# Altium schematic sheet PWR.SchDoc(PWR)
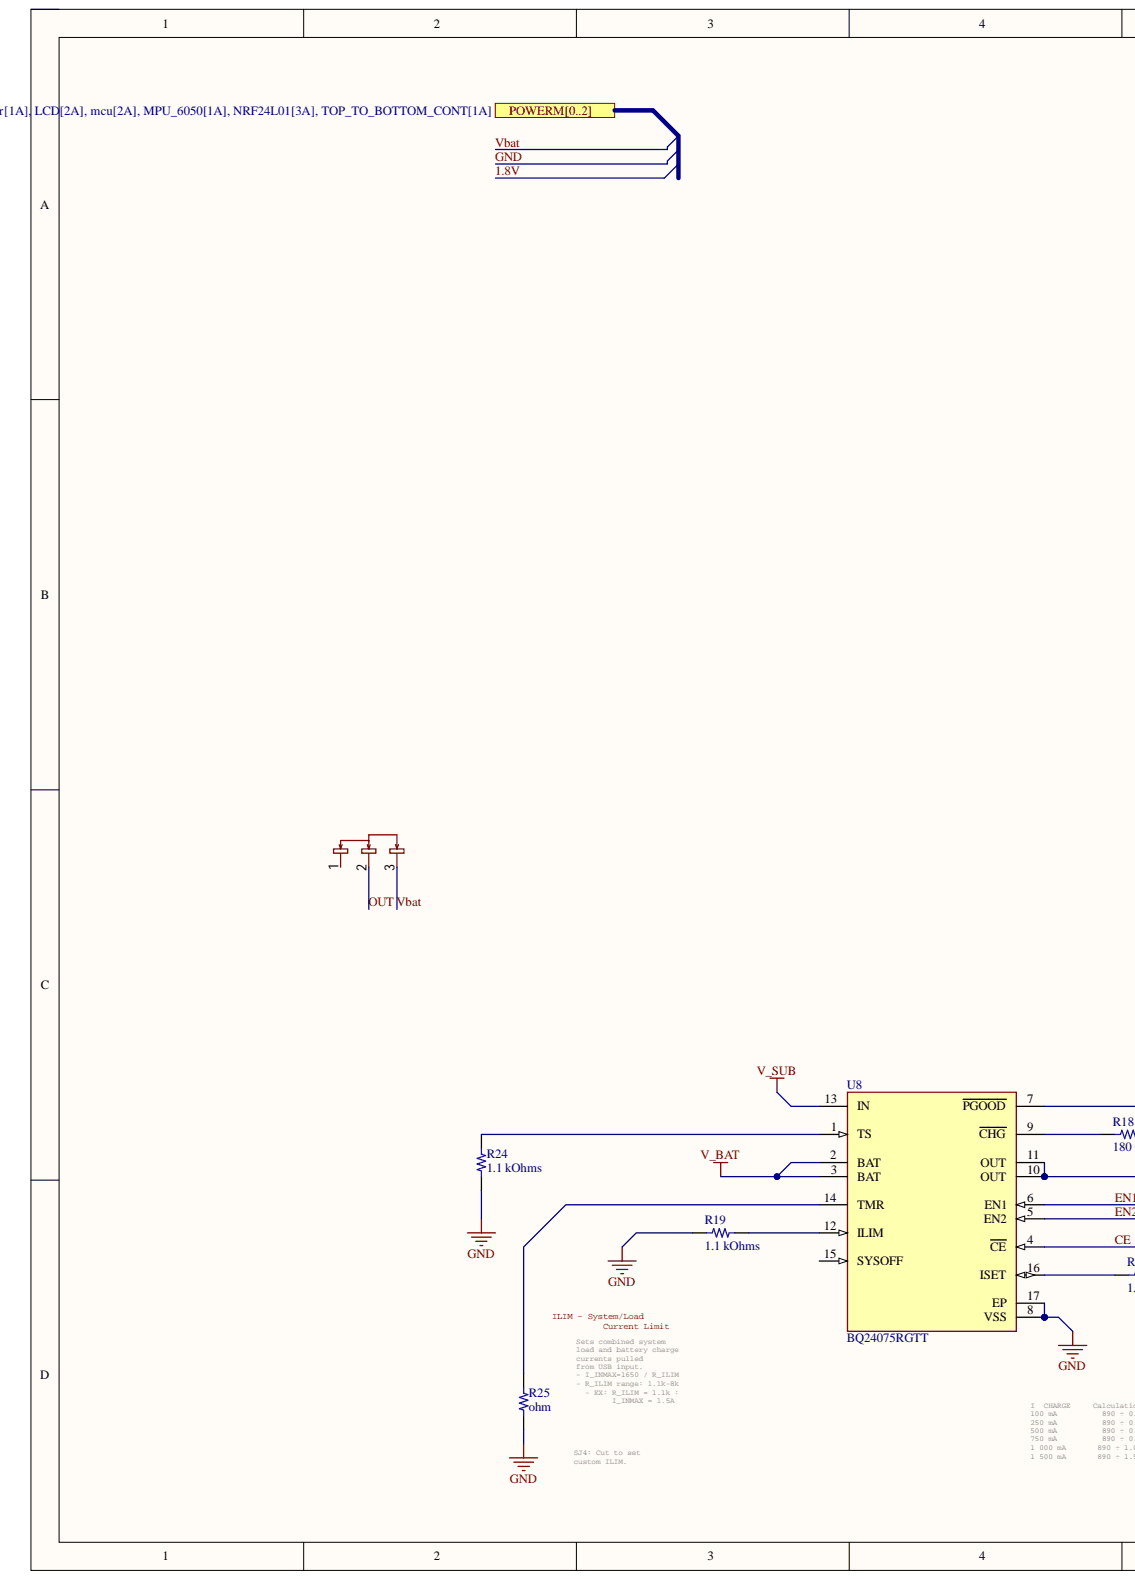
[diagram p0: PWR.SchDoc, left]
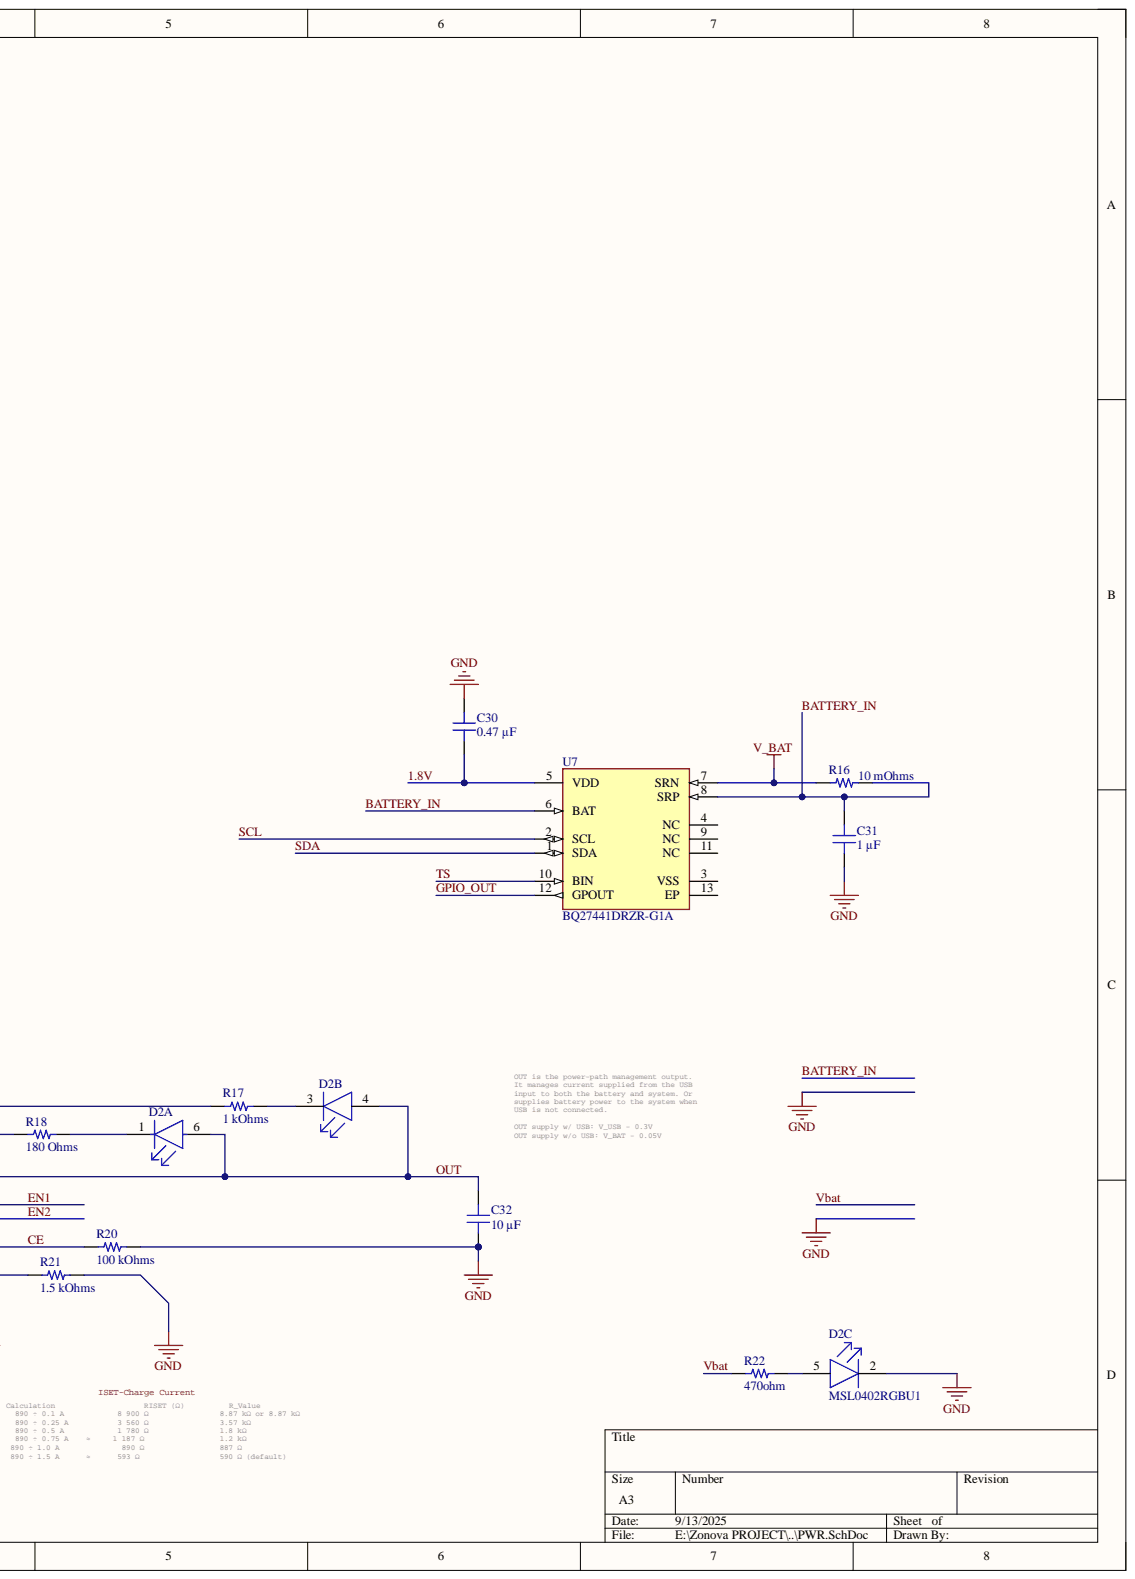
[diagram p1: PWR.SchDoc, right]

## Parts
C30  0.47 µF  [CAP 0402_1005]
C31  1 µF  [MURATA GRM155 0402_1005]
C32  10 µF  [CAP 0402_1005]
D2  MSL0402RGBU1  [FP-MSL0402-MFG]  (parts A,B,C)
R16  10 mOhms  [RES 0402_1005]
R17  1 kOhms  [RES 0402_1005]
R18  180 Ohms  [YAGEO RES 0402_1005]
R19  1.1 kOhms  [YAGEO RES 0402_1005]
R20  100 kOhms  [RES 0402_1005]
R21  1.5 kOhms  [RES 0402_1005]
R22  470ohm  [RES 0402_1005]
R24  1.1 kOhms  [YAGEO RES 0402_1005]
R25  ohm  [YAGEO RES 0402_1005]
SW2  MST-12D18G4 SPDT Slide Switch  [SW-SMD_MST-12D18G4-SPDT-SLIDE-SWITCH]  (value not drawn)
U7  BQ27441DRZR-G1A  [FP-DRZ0012A-MFG]
U8  BQ24075RGTT  [FP-RGT0016C-IPC_C]

## Ports
POWERM0, POWERM1, POWERM2, POWERM[0..2]

## Nets
V_BAT: R16.1 U7.7(SRN) U8.2(BAT) U8.3(BAT)
V_SUB: U8.13(IN)
1.8V: C30.2 U7.5(VDD)
BATTERY_IN: C31.2 R16.2 U7.6(BAT) U7.8(SRP)
CE: R20.2 U8.4(CE)
EN1: U8.6(EN1)
EN2: U8.5(EN2)
GND: C30.1 C31.1 C32.1 D2.2 R19.1 R20.1 R21.2 R24.1 R25.1 U8.8(VSS) U8.17(EP)
GPIO_OUT: U7.12(GPOUT)
OUT: C32.2 D2.4 D2.6 SW2.2 U8.10(OUT) U8.11(OUT)
SCL: U7.2(SCL)
SDA: U7.1(SDA)
TS: U7.10(BIN)
Vbat: R22.1 SW2.3
NetD2_1: D2.1 R18.1
NetD2_3: D2.3 R17.2
NetD2_5: D2.5 R22.2
NetR17_1: R17.1 U8.7(PGOOD)
NetR18_2: R18.2 U8.9(CHG)
NetR19_2: R19.2 U8.12(ILIM)
NetR21_1: R21.1 U8.16(ISET)
NetR24_2: R24.2 U8.1(TS)
NetR25_2: R25.2 U8.14(TMR)
N00009_0: ; port POWERM[0..2]
N00009_1: ; port POWERM[0..2]
N00009_2: ; port POWERM[0..2]

Not connected: SW2 1; U7 3(VSS) 4(NC) 9(NC) 11(NC) 13(EP); U8 15(SYSOFF)

## Library data of the parts
C30 = C1005X5R1V474K050BE: CAP CER 0.47UF 35V X5R 0402; manufacturer: TDK Corporation; Database Table Name: Capacitors - Ceramic - 0402; Tolerance: ±10%; Applications: Boardflex Sensitive; Capacitance: 0.47 µF; Component Kind: Standard; componentlink1description: Datasheet; componentlink1url: https://product.tdk.com/info/en/catalog/datasheets/mlcc_commercial_soft_en.pdf?ref_disty=digikey; componentlink2description: DigiKey Link; componentlink2url: https://www.digikey.com/en/products/detail/tdk-corporation/C1005X5R1V474K050BE/7907744; Device Package: 0402 (1005 Metric); Features: Soft Termination; lastupdated: 2/9/2024 8:04:38 PM; Library Name: Celestial Altium Library - altium_library.DbLib; Lifecycle Status: Active; Minimum Order: 1; Mounting Type: Surface Mount, MLCC; Operating Temperature: -55°C ~ 85°C; Package / Case: 0402 (1005 Metric); packaging: TapeAndReel; Part Number: C1005X5R1V474K050BE; Part Status: Active; partid: 1797; price: 0.01; series: C; Size / Dimension: 0.039" L x 0.020" W (1.00mm x 0.50mm); Supplier 1: DigiKey; Supplier Part Number 1: 445-175220-1-ND; Temperature Coefficient: X5R; Thickness (Max): 0.024" (0.60mm); value: 0.47 µF; Voltage - Rated: 35V
C31 = GRM155C71A105KE11D: CAP CER 1UF 10V X7S 0402; manufacturer: Murata Electronics; Database Table Name: Capacitors - Ceramic - 0402; Tolerance: ±10%; Applications: General Purpose; Capacitance: 1 µF; Component Kind: Standard; componentlink1description: Datasheet; componentlink1url: https://search.murata.co.jp/Ceramy/image/img/A01X/G101/ENG/GRM155C71A105KE11-01.pdf; componentlink2description: DigiKey Link; componentlink2url: https://www.digikey.com/en/products/detail/murata-electronics/GRM155C71A105KE11D/6606086; Device Package: 0402 (1005 Metric); lastupdated: 8/27/2024 12:42:54 PM; Library Name: Celestial Altium Library - altium_library.DbLib; Lifecycle Status: Active; Minimum Order: 1; Mounting Type: Surface Mount, MLCC; Operating Temperature: -55°C ~ 125°C; Package / Case: 0402 (1005 Metric); packaging: TapeAndReel; Part Number: GRM155C71A105KE11D; Part Status: Active; partid: 1749; price: 0.01; series: GRM; Size / Dimension: 0.039" L x 0.020" W (1.00mm x 0.50mm); Supplier 1: DigiKey; Supplier Part Number 1: 490-14457-1-ND; Temperature Coefficient: X7S; Thickness (Max): 0.024" (0.60mm); value: 1 µF; Voltage - Rated: 10V
C32 = CL05A106MP8NUB8: CAP CER 10UF 10V X5R 0402; Database Table Name: Capacitors - Ceramic - 0402; Capacitance: 10 µF; Component Kind: Standard; Library Name: Celestial Altium Library - altium_library.DbLib; Part Number: CL05A106MP8NUB8
D2 = CMP-08952-000010-1: LED RGB DIFFUSED 1816 SMD; Manufacturer: Rohm; Colour: Blue, Green, Red; Forward Current: 20mA; Forward Voltage: 3.3/3.5/2.1V; Led Orientation: Top View; Luminous Intensity: 180/550/400mcd; Power Dissipation: 180mW; Reverse Voltage: 5V; Wavelength: 470/527/624nm; Automotive: No; Category: Diode; Component Kind: Standard; ComponentLink2Description: Datasheet; ComponentLink2URL: https://d1d2qsbl8m0m72.cloudfront.net/en/products/databook/datasheet/opto/led/chip_multi/msl0402rgb-e.pdf; Device Class L1: Optoelectronics; Device Class L2: LEDs; Device Class L3: unset; Digikey Description: LED RGB DIFFUSED 1816 SMD; Height: 0.6mm; Lead Free: Yes; Library Name: Altium Content Vault; Manufacturer Part Number: MSL0402RGBU1; Octopart: https://octopart.com/msl0402rgbu1-rohm-74168054; Package: MSL0402; RoHS: TRUE; Temperature: -40°C to +85°C; Temperature Range High: +85°C; Temperature Range Low: -40°C
R16 = PMR01ZZPJU10L: RES 0.01 OHM 5% 1/5W 0402; Manufacturer: Rohm Semiconductor; Database Table Name: Resistors - Surface Mount - 0402; Power (Watts): 0.2W, 1/5W; Temperature Coefficient: 0/ +200ppm/°C; Component Kind: Standard; ComponentLink1Description: Datasheet; ComponentLink1URL: https://www.rohm.com/datasheet/PMR18EZPFV/pmr-e; ComponentLink2Description: DigiKey Link; ComponentLink2URL: https://www.digikey.com/en/products/detail/rohm-semiconductor/PMR01ZZPJU10L/5218668; Composition: Metal Element; Device Package: 0402 (1005 Metric); Features: Automotive AEC-Q200, Current Sense; Height - Seated (Max): 0.014" (0.35mm); LastUpdated: 2/9/2024 8:30:21 PM; Library Name: Celestial Altium Library - altium_library.DbLib; Lifecycle Status: Active; Minimum Order: 1; Number of Terminations: 2; Operating Temperature: -55°C ~ 155°C; Package / Case: 0402 (1005 Metric); Packaging: TapeAndReel; Part Number: PMR01ZZPJU10L; Part Status: Active; PartId: 3064; Price: 0.28; Ratings: AEC-Q200; Resistance: 10 mOhms; Series: PMR; Size / Dimension: 0.039" L x 0.020" W (1.00mm x 0.50mm); Supplier 1: DigiKey; Supplier Device Package: 0402; Supplier Part Number 1: RHM1693-1-ND; Tolerance: ±5%; Value: 10 mOhms
R17 = RQ73C1E1K0BTD: RES 1 KOHMS 0.1% 1/16W 0402; Database Table Name: Resistors - Surface Mount - 0402; Component Kind: Standard; Library Name: Celestial Altium Library - altium_library.DbLib; Part Number: RQ73C1E1K0BTD; Value: 1 kOhms
R18 = RT0402BRD07180RL: RES SMD 180 OHM 0.1% 1/16W 0402; Database Table Name: Resistors - Surface Mount - 0402; Component Kind: Standard; Library Name: Celestial Altium Library - altium_library.DbLib; Part Number: RT0402BRD07180RL; Value: 180 Ohms
R19 R24 R25 = RC0402FR-071K1L: RES 1.1K OHM 1% 1/16W 0402; Database Table Name: Resistors - Surface Mount - 0402; Component Kind: Standard; Library Name: Celestial Altium Library - altium_library.DbLib; Part Number: RC0402FR-071K1L
  R19 R24: Value: 1.1 kOhms
  R25: Value: ohm
R20 = RP73PF1E100KBTD: RES 100K OHM 0.1% 1/10W 0402; Database Table Name: Resistors - Surface Mount - 0402; Component Kind: Standard; Library Name: Celestial Altium Library - altium_library.DbLib; Part Number: RP73PF1E100KBTD; Value: 100 kOhms
R21 = RR0510P-152-D: RES SMD 1.5K OHM 0.5% 1/16W 0402; Database Table Name: Resistors - Surface Mount - 0402; Component Kind: Standard; Library Name: Celestial Altium Library - altium_library.DbLib; Part Number: RR0510P-152-D; Value: 1.5 kOhms
R22 = RR0510P-152-D: RES SMD 1.5K OHM 0.5% 1/16W 0402; Database Table Name: Resistors - Surface Mount - 0402; Value: 1.5 kOhms; Component Kind: Standard; Library Name: Celestial Altium Library - altium_library.DbLib; Part Number: RR0510P-152-D
SW2 = MST-12D18G4 SPDT Slide Switch: Manufacturer: SHOU HAN(首韩); Add into BOM: yes; Component Kind: Standard; Convert to PCB: yes; Datasheet: https://item.szlcsc.com/datasheet/MST-12D18G4%2520SPDT%2520Slide%2520Switch/51384142.html; Device: MST-12D18G4 SPDT Slide Switch; JLCPCB Part Class: Extended Part; LCSC Part Name: 卧贴两档 滑动开关; Manufacturer Part: MST-12D18G4 SPDT Slide Switch; PCB3D: SW-SMD_MST-12D18G4-SPDT-SLIDE-SWITCH; Supplier: LCSC; Supplier Footprint: SMD; Supplier Part: C49023767; Symbol: MST-12D18G4 SPDT SLIDE SWITCH
U7 = CMP-04911-000008-1: IC BATT FUEL GAUGE LI-ION 12SON; Manufacturer: Texas Instruments; Max Supply Voltage: 4.5V; Min Supply Voltage: 2.45V; Nominal Supply Current: 93uA; Number Of Cells: 1; Output Voltage: 1.8V; Standoff Height: 0mm; Ambient Temperature Range High: +85°C; Ambient Temperature Range Low: -40°C; Automotive: No; Category: IC; Component Kind: Standard; ComponentLink2Description: Datasheet; ComponentLink2URL: http://www.ti.com/lit/gpn/bq27441-g1; Device Class L1: Integrated Circuits (ICs); Device Class L2: Power Management ICs; Device Class L3: Battery Management; Digikey Description: IC BATT FUEL GAUGE LI-ION 12SON; Height: 1mm; Lead Free: Yes; Library Name: Altium Content Vault; Manufacturer Part Number: BQ27441DRZR-G1A; Mouser Description: System-Side Impedance Track? Battery Fuel (Gas) Gauge 12-SON -40 to 85; Number Of Outputs: 1; Octopart: https://octopart.com/bq27441drzr-g1a-texas+instruments-30555829; Package: DRZ0012A; RoHS: TRUE; Temperature: -40°C to +85°C; Temperature Range High: +85°C; Temperature Range Low: -40°C
U8 = CMP-0321-00236-3: IC LI-ION CHARGE/PWR MGMT 16-QFN; Battery Type: LITHIUM-ION; Max Charge Current: 1.5A; Max Input Voltage: 26V; Max Output Current: 4.5A; Max Output Voltage: 5.6V; Max Supply Voltage: 6.4V; Min Input Voltage: 4.3V; Min Output Voltage: 5.4V; Min Supply Voltage: 4.35V; Number of Cells: 1; Output Voltage: 4.2V; Case/Package: QFN; Component Kind: Standard; Contact Plating: Gold; Height: 0.95mm; Lead Free: Lead Free; Length: 3.15mm; Library Name: Altium Content Vault; Max Operating Temperature: 85°C; Min Operating Temperature: -40°C; Number of Pins: 16; Output Current: 1.5A; Package Quantity: 1; Packaging: Tape and Reel; Radiation Hardening: No; REACH SVHC: No SVHC; Series: -; Termination: SMD/SMT; Weight: 0.000808oz; Width: 3.15mm
